# Altium schematic sheet wifi_relay.SchDoc
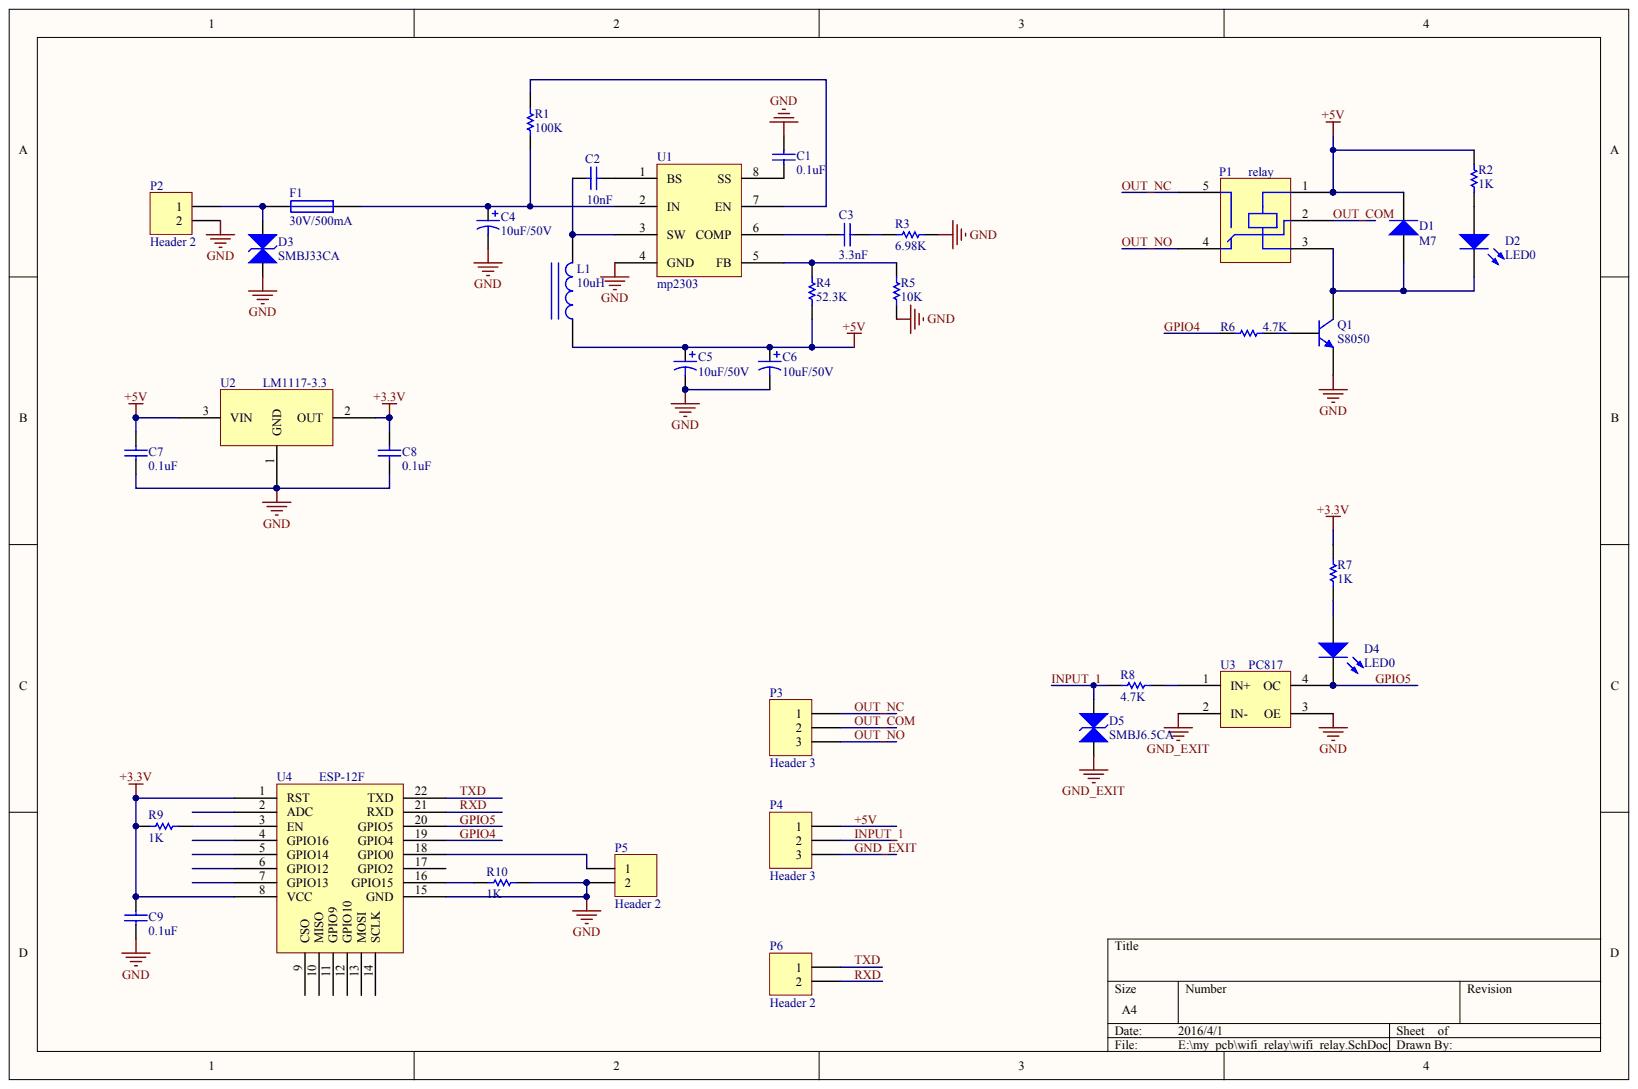
[diagram p0: wifi_relay.SchDoc]

## Parts
C1  0.1uF
C2  10nF
C3  3.3nF
C4  10uF/50V
C5  10uF/50V
C6  10uF/50V
C7  0.1uF
C8  0.1uF
C9  0.1uF
D1  M7
D2  LED0
D3  SMBJ33CA
D4  LED0
D5  SMBJ6.5CA
F1  30V/500mA
L1  10uH
P1  relay
P2  Header 2
P3  Header 3
P4  Header 3
P5  Header 2
P6  Header 2
Q1  S8050
R1  100K
R2  1K
R3  6.98K
R4  52.3K
R5  10K
R6  4.7K
R7  1K
R8  4.7K
R9  1K
R10  1K
U1  mp2303
U2  LM1117-3.3
U3  PC817
U4  ESP-12F

## Nets
+3.3V: C8.2 C9.2 R7.2 R9.2 U2.2 U4.1(RST) U4.8(VCC)
GND: C1.1 C4.2 C5.2 C6.2 C7.1 C8.1 C9.1 D3.2 P2.2 P5.2 Q1.3 R3.1 R5.2 R10.1 U1.4(GND) U2.1 U3.3(OE) U4.15(GND)
+5V: C5.1 C6.1 C7.2 D1.2 L1.1 P1.1 P4.1 R2.2 R4.1 U2.3
GND_EXIT: D5.2 P4.3 U3.2(IN-)
GPIO4: R6.1 U4.19(GPIO4)
GPIO5: D4.2 U3.4(OC) U4.20(GPIO5)
INPUT_1: D5.1 P4.2 R8.2
OUT_COM: P1.2 P3.2
OUT_NC: P1.5 P3.1
OUT_NO: P1.4 P3.3
RXD: P6.2 U4.21(RXD)
TXD: P6.1 U4.22(TXD)
NetC1_2: C1.2 U1.8(SS)
NetC2_1: C2.1 U1.1(BS)
NetC2_2: C2.2 L1.2 U1.3(SW)
NetC3_1: C3.1 R3.2
NetC3_2: C3.2 U1.6(COMP)
NetC4_1: C4.1 F1.2 R1.2 U1.2(IN)
NetD1_1: D1.1 D2.2 P1.3 Q1.2
NetD2_1: D2.1 R2.1
NetD3_1: D3.1 F1.1 P2.1
NetD4_1: D4.1 R7.1
NetP5_1: P5.1 U4.18(GPIO0)
NetQ1_1: Q1.1 R6.2
NetR1_1: R1.1 U1.7(EN)
NetR4_2: R4.2 R5.1 U1.5(FB)
NetR8_1: R8.1 U3.1(IN+)
NetR9_1: R9.1 U4.3(EN)
NetR10_2: R10.2 U4.16(GPIO15)

Not connected: U4 2(ADC) 4(GPIO16) 5(GPIO14) 6(GPIO12) 7(GPIO13) 9(CSO) 10(MISO) 11(GPIO9) 12(GPIO10) 13(MOSI) 14(SCLK) 17(GPIO2)

## Library data of the parts
C1-C9 = (no symbol name): Value: 100pF; Published: 8-Jun-2000; LatestRevisionDate: 17-Jul-2002; LatestRevisionNote: Re-released for DXP Platform.; Publisher: Altium Limited
  C1-C3 C7-C9: PackageReference: RAD-0.3; PackageDescription: Radial Cap, Thru-Hole; 2 Leads; 0.3 in Pin Spacing
  C4-C6: PackageReference: RB7.6-15; PackageDescription: Polarized Capacitor (Radial); 2 Leads
D5 = (no symbol name): Value: SMBJ33CA
L1 = (no symbol name): Value: 10mH; Published: 24-Mar-1999; LatestRevisionDate: 17-Jul-2002; LatestRevisionNote: Re-released for DXP Platform.; PackageReference: AXIAL-0.9; PackageDescription: Axial Device, Thru-Hole; 2 Leads; 0.9 in Pin Spacing; Publisher: Altium Limited
R1 R3-R5 = (no symbol name): Value: 1K; Published: 8-Jun-2000; LatestRevisionDate: 17-Jul-2002; LatestRevisionNote: Re-released for DXP Platform.; PackageReference: AXIAL-0.3; PackageDescription: Axial Device, Thru-Hole; 2 Leads; 0.3 in Pin Spacing; Publisher: Altium Limited
R2 R6-R8 = (no symbol name): Value: 10K; Published: 8-Jun-2000; LatestRevisionDate: 17-Jul-2002; LatestRevisionNote: Re-released for DXP Platform.; PackageReference: AXIAL-0.3; Publisher: Altium Limited
D1-D4 F1 P1-P6 Q1 R9 R10 U1-U4 = (no symbol name)
  D1: Published: 8-Jun-2000; LatestRevisionDate: 17-Jul-2002; LatestRevisionNote: Re-released for DXP Platform.; PackageVersion: Sep-1996; PackageReference: SMC; PackageDescription: DO-214-AB/SMC; 2 C-Bend Leads; Body 7.9 x 5.9 mm, inc. leads (LxW); Code_JEDEC: DO-214-AB
  D2 D4: Published: 8-Jun-2000; LatestRevisionDate: 08-Jul-2005; LatestRevisionNote: Mech Layer 1 removed.; PackageReference: LED-0; PackageDescription: LED; 2 Leads
  D3: Value: SMBJ33CA
  F1: Published: 8-Jun-2000; LatestRevisionDate: 17-Jul-2002; LatestRevisionNote: Re-released for DXP Platform.; PackageReference: PIN-W2/E2.8; PackageDescription: Thru-Hole; 2 Leads
  P2-P6: LatestRevisionDate: 17-Jul-2002; LatestRevisionNote: Re-released for DXP Platform.
  Q1: Published: 8-Jun-2000; LatestRevisionDate: 15-Jan-2003; LatestRevisionNote: PCB Footprint 'BCY-W3/D4.7' replaced; DatasheetVersion: 1997; PackageVersion: Sep-1998; PackageReference: TO-92A; PackageDescription: TO, Flat Index; 3 In-Line, Axial Leads; Body Dia. 4.5mm; Leads 0.51 x 0.48 mm (max); ComponentLink1Description: Manufacturer Link; ComponentLink1URL: http://www.fairchildsemi.com/; ComponentLink2Description: Datasheet; ComponentLink2URL: http://www.fairchildsemi.com/ds/2N%2F2N3904.pdf
  R9 R10: Published: 8-Jun-2000; LatestRevisionDate: 17-Jul-2002; LatestRevisionNote: Re-released for DXP Platform.; PackageReference: AXIAL-0.3; PackageDescription: Axial Device, Thru-Hole; 2 Leads; 0.3 in Pin Spacing; Value: 1K
  D1 D2 D4 F1 P2-P6 Q1 R9 R10: Publisher: Altium Limited
